# Altium schematic sheet Sway Cry Rev C - Sheet 1.SchDoc
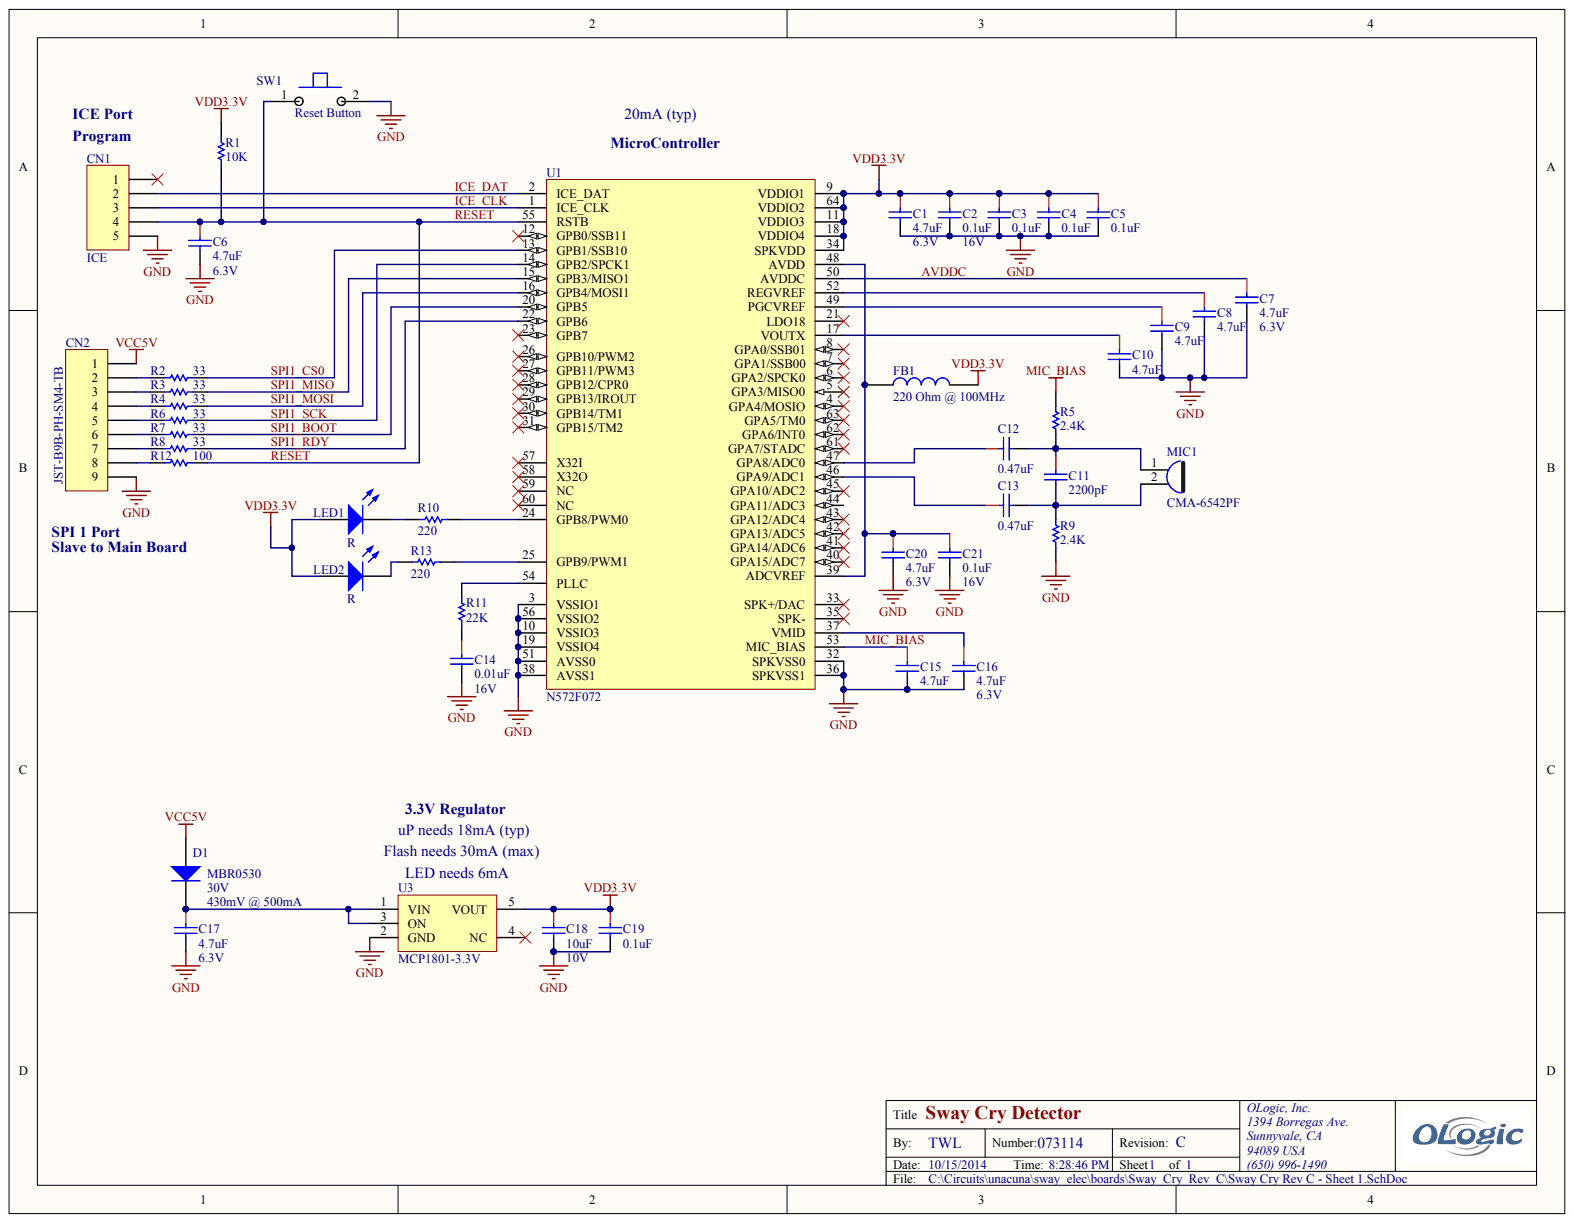
[diagram p0: Sway Cry Rev C - Sheet 1.SchDoc]

## Parts
C1  4.7uF  Voltage - Rated: 6.3V
C2  0.1uF  Voltage - Rated: 16V
C3  0.1uF  Voltage - Rated: 16V
C4  0.1uF
C5  0.1uF
C6  4.7uF  Voltage - Rated: 6.3V
C7  4.7uF  Voltage - Rated: 6.3V
C8  4.7uF
C9  4.7uF
C10  4.7uF
C11  2200pF
C12  0.47uF
C13  0.47uF
C14  0.01uF  Voltage - Rated: 16V
C15  4.7uF
C16  4.7uF  Voltage - Rated: 6.3V
C17  4.7uF  Voltage - Rated: 6.3V
C18  10uF  Voltage - Rated: 10V
C19  0.1uF
C20  4.7uF  Voltage - Rated: 6.3V
C21  0.1uF  Voltage - Rated: 16V
CN1  ICE
CN2  JST-B9B-PH-SM4-TB
D1  MBR0530  Voltage - DC Reverse (Vr) (Max): 30V
FB1  220 Ohm @ 100MHz
LED1  R
LED2  R
MIC1  CMA-6542PF
R1  10K
R2  33
R3  33
R4  33
R5  2.4K
R6  33
R7  33
R8  33
R9  2.4K
R10  220
R11  22K
R12  100
R13  220
SW1  Reset Button
U1  N572F072
U3  MCP1801-3.3V

## Nets
GND: C1.2 C2.2 C3.2 C4.2 C5.2 C6.2 C7.2 C8.2 C9.2 C10.2 C14.2 C15.2 C16.2 C17.2 C18.2 C19.2 C20.2 C21.2 CN1.5 CN2.9 R9.1 SW1.2 U1.3(VSSIO1) U1.10(VSSIO3) U1.19(VSSIO4) U1.32(SPKVSS0) U1.36(SPKVSS1) U1.38(AVSS1) U1.51(AVSS0) U1.56(VSSIO2) U3.2(GND)
VCC5V: CN2.1 D1.1
VDD3.3V: C1.1 C2.1 C3.1 C4.1 C5.1 C18.1 C19.1 FB1.2 LED1.1 LED2.1 R1.2 U1.9(VDDIO1) U1.11(VDDIO3) U1.18(VDDIO4) U1.34(SPKVDD) U1.64(VDDIO2) U3.5(VOUT)
AVDDC: C7.1 U1.50(AVDDC)
ICE_CLK: CN1.3 U1.1(ICE_CLK)
ICE_DAT: CN1.2 U1.2(ICE_DAT)
MIC_BIAS: C15.1 R5.2 U1.53(MIC_BIAS)
RESET: C6.1 CN1.4 R1.1 R12.1 SW1.1 U1.55(RSTB)
SPI1_BOOT: R7.1 U1.20(GPB5)
SPI1_CS0: R2.1 U1.13(GPB1/SSB10)
SPI1_MISO: R3.1 U1.15(GPB3/MISO1)
SPI1_MOSI: R4.1 U1.16(GPB4/MOSI1)
SPI1_RDY: R8.1 U1.22(GPB6)
SPI1_SCK: R6.1 U1.14(GPB2/SPCK1)
NetC8_1: C8.1 U1.52(REGVREF)
NetC9_1: C9.1 U1.49(PGCVREF)
NetC10_1: C10.1 U1.17(VOUTX)
NetC11_1: C11.1 C12.2 MIC1.1 R5.1
NetC11_2: C11.2 C13.2 MIC1.2 R9.2
NetC12_1: C12.1 U1.47(GPA8/ADC0)
NetC13_1: C13.1 U1.46(GPA9/ADC1)
NetC14_1: C14.1 R11.1
NetC16_1: C16.1 U1.37(VMID)
NetC17_1: C17.1 D1.2 U3.1(VIN) U3.3(ON)
NetC20_1: C20.1 C21.1 FB1.1 U1.39(ADCVREF) U1.48(AVDD)
NetCN2_2: CN2.2 R2.2
NetCN2_3: CN2.3 R3.2
NetCN2_4: CN2.4 R4.2
NetCN2_5: CN2.5 R6.2
NetCN2_6: CN2.6 R7.2
NetCN2_7: CN2.7 R8.2
NetCN2_8: CN2.8 R12.2
NetLED1_2: LED1.2 R10.1
NetLED2_2: LED2.2 R13.1
NetR10_2: R10.2 U1.24(GPB8/PWM0)
NetR11_2: R11.2 U1.54(PLLC)
NetR13_2: R13.2 U1.25(GPB9/PWM1)

Not connected: CN1 1; U1 4(GPA4/MOSIO) 5(GPA3/MISO0) 6(GPA2/SPCK0) 7(GPA1/SSB00) 8(GPA0/SSB01) 12(GPB0/SSB11) 21(LDO18) 23(GPB7) 26(GPB10/PWM2) 27(GPB11/PWM3) 28(GPB12/CPR0) 29(GPB13/IROUT) 30(GPB14/TM1) 31(GPB15/TM2) 33(SPK+/DAC) 35(SPK-) 40(GPA15/ADC7) 41(GPA14/ADC6) 42(GPA13/ADC5) 43(GPA12/ADC4) 44(GPA11/ADC3) 45(GPA10/ADC2) 57(X32I) 58(X32O) 59(NC) 60(NC) 61(GPA7/STADC) 62(GPA6/INT0) 63(GPA5/TM0); U3 4(NC)

## Library data of the parts
C1 C6 C7 C16 C17 C20 = (no symbol name): Manufacturer: TDK Corporation; Manufacturer Part Number: C1608X5R0J475K080AB; Capacitance: 4.7µF; Tolerance: ±10%; Temperature Coefficient: X5R; Supplier 1: Digi-Key; RoHS: RoHS Compliant; Category: Capacitors; Stock 1: 208265; Pricing 1: 1=0.18, 10=0.122, 100=0.0581, 250=0.04896, 500=0.041, 1000=0.033 (USD); Packaging: Cut Tape (CT); Mounting Type: Surface Mount, MLCC; Operating Temperature: -55°C ~ 85°C; Applications: General Purpose; Ratings: -; Package / Case: 0603 (1608 Metric); Size / Dimension: 0.063" L x 0.031" W (1.60mm x 0.80mm); Height - Seated (Max): -; Thickness (Max): 0.035" (0.90mm); Lead Spacing: -; Features: -; Lead Style: -; ComponentLink1URL: http://product.tdk.com/capacitor/mlcc/en/documents/mlcc_commercial_general_en.pdf; ComponentLink1Description: http://product.tdk.com/capacitor/mlcc/en/documents/mlcc_commercial_general_en.pdf; Supplier Part Number 1: 445-5178-1-ND
C2 C3 C21 = (no symbol name): Manufacturer: Kemet; Manufacturer Part Number: C0603C104K4RACTU; Capacitance: 0.1µF; Tolerance: ±10%; Temperature Coefficient: X7R; Supplier 1: Digi-Key; RoHS: RoHS Compliant; Category: Capacitors; Stock 1: 2360000; Pricing 1: 4000=0.00414, 8000=0.00378, 12000=0.0036, 28000=0.00342, 100000=0.00248 (USD); Packaging: Tape & Reel (TR); Mounting Type: Surface Mount, MLCC; Operating Temperature: -55°C ~ 125°C; Applications: General Purpose; Ratings: -; Package / Case: 0603 (1608 Metric); Size / Dimension: 0.063" L x 0.031" W (1.60mm x 0.80mm); Height - Seated (Max): -; Thickness (Max): 0.034" (0.87mm); Lead Spacing: -; Features: -; Lead Style: -; ComponentLink1URL: http://www.kemet.com/datasheets&C0603C104K4RACTU; ComponentLink1Description: http://www.kemet.com/datasheets&C0603C104K4RACTU; Supplier Part Number 1: 399-1096-2-ND
C4 C5 C19 = (no symbol name): Manufacturer: Kemet; Manufacturer Part Number: C0603C104K4RACTU; Capacitance: 0.1µF; Tolerance: ±10%; Temperature Coefficient: X7R; Voltage - Rated: 16V; Supplier 1: Digi-Key; RoHS: RoHS Compliant; Category: Capacitors; Stock 1: 2360000; Pricing 1: 4000=0.00414, 8000=0.00378, 12000=0.0036, 28000=0.00342, 100000=0.00248 (USD); Packaging: Tape & Reel (TR); Mounting Type: Surface Mount, MLCC; Operating Temperature: -55°C ~ 125°C; Applications: General Purpose; Ratings: -; Package / Case: 0603 (1608 Metric); Size / Dimension: 0.063" L x 0.031" W (1.60mm x 0.80mm); Height - Seated (Max): -; Thickness (Max): 0.034" (0.87mm); Lead Spacing: -; Features: -; Lead Style: -; ComponentLink1URL: http://www.kemet.com/datasheets&C0603C104K4RACTU; ComponentLink1Description: http://www.kemet.com/datasheets&C0603C104K4RACTU; Supplier Part Number 1: 399-1096-2-ND
C8-C10 C15 = (no symbol name): Manufacturer: TDK Corporation; Manufacturer Part Number: C1608X5R0J475K080AB; Capacitance: 4.7µF; Tolerance: ±10%; Temperature Coefficient: X5R; Voltage - Rated: 6.3V; Supplier 1: Digi-Key; RoHS: RoHS Compliant; Category: Capacitors; Stock 1: 208265; Pricing 1: 1=0.18, 10=0.122, 100=0.0581, 250=0.04896, 500=0.041, 1000=0.033 (USD); Packaging: Cut Tape (CT); Mounting Type: Surface Mount, MLCC; Operating Temperature: -55°C ~ 85°C; Applications: General Purpose; Ratings: -; Package / Case: 0603 (1608 Metric); Size / Dimension: 0.063" L x 0.031" W (1.60mm x 0.80mm); Height - Seated (Max): -; Thickness (Max): 0.035" (0.90mm); Lead Spacing: -; Features: -; Lead Style: -; ComponentLink1URL: http://product.tdk.com/capacitor/mlcc/en/documents/mlcc_commercial_general_en.pdf; ComponentLink1Description: http://product.tdk.com/capacitor/mlcc/en/documents/mlcc_commercial_general_en.pdf; Supplier Part Number 1: 445-5178-1-ND
C11 = (no symbol name): Manufacturer: Murata Electronics North America; Manufacturer Part Number: GRM1885C1H222JA01D; Voltage - Rated: 50V; Tolerance: ±5%; Temperature Coefficient: C0G, NP0; Supplier 1: Digi-Key; RoHS: RoHS Compliant; Category: Capacitors; Stock 1: 132131; Pricing 1: 1=0.12, 10=0.084, 50=0.0484, 100=0.0399, 250=0.0336, 500=0.02814, 1000=0.0231 (USD); Packaging: Cut Tape (CT); Capacitance: 2200pF; Mounting Type: Surface Mount, MLCC; Operating Temperature: -55°C ~ 125°C; Applications: General Purpose; Ratings: -; Package / Case: 0603 (1608 Metric); Size / Dimension: 0.063" L x 0.031" W (1.60mm x 0.80mm); Height - Seated (Max): -; Thickness (Max): 0.035" (0.90mm); Lead Spacing: -; Features: -; Lead Style: -; ComponentLink1URL: http://www.murata.com/products/catalog/pdf/c02e.pdf; ComponentLink1Description: http://www.murata.com/products/catalog/pdf/c02e.pdf; Supplier Part Number 1: 490-1459-1-ND
C12 C13 = (no symbol name): Manufacturer: Kemet; Manufacturer Part Number: C0603C474K4RACTU; Capacitance: 0.47µF; Voltage - Rated: 16V; Tolerance: ±10%; Temperature Coefficient: X7R; Supplier 1: Digi-Key; RoHS: RoHS Compliant; Category: Capacitors; Stock 1: 84679; Pricing 1: 1=0.15, 10=0.1, 50=0.0576, 100=0.0475, 250=0.04, 500=0.0335, 1000=0.0275 (USD); Packaging: Cut Tape (CT); Mounting Type: Surface Mount, MLCC; Operating Temperature: -55°C ~ 125°C; Applications: General Purpose; Ratings: -; Package / Case: 0603 (1608 Metric); Size / Dimension: 0.063" L x 0.031" W (1.60mm x 0.80mm); Height - Seated (Max): -; Thickness (Max): 0.034" (0.87mm); Lead Spacing: -; Features: -; Lead Style: -; ComponentLink1URL: http://www.kemet.com/docfinder?Partnumber=C0603C474K4RACTU; ComponentLink1Description: http://www.kemet.com/docfinder?Partnumber=C0603C474K4RACTU; Supplier Part Number 1: 399-4922-1-ND
C14 = (no symbol name): Manufacturer: Kemet; Manufacturer Part Number: C0603C103K4RACTU; Capacitance: 10000pF; Tolerance: ±10%; Temperature Coefficient: X7R; Supplier 1: Digi-Key; RoHS: RoHS Compliant; Category: Capacitors; Stock 1: 40000; Pricing 1: 4000=0.042, 8000=0.0399, 12000=0.0378, 28000=0.0357, 100000=0.02835 (USD); Packaging: Tape & Reel (TR); Mounting Type: Surface Mount, MLCC; Operating Temperature: -55°C ~ 125°C; Applications: General Purpose; Ratings: -; Package / Case: 0603 (1608 Metric); Size / Dimension: 0.063" L x 0.031" W (1.60mm x 0.80mm); Height - Seated (Max): -; Thickness (Max): 0.034" (0.87mm); Lead Spacing: -; Features: -; Lead Style: -; ComponentLink1URL: http://www.kemet.com/datasheets&C0603C103K4RACTU; ComponentLink1Description: http://www.kemet.com/datasheets&C0603C103K4RACTU; Supplier Part Number 1: 399-7841-2-ND
C18 = (no symbol name): Manufacturer: Taiyo Yuden; Manufacturer Part Number: LMK212F106ZG-T; Capacitance: 10µF; Tolerance: -20%, +80%; Temperature Coefficient: Y5V (F); Supplier 1: Digi-Key; RoHS: RoHS Compliant; Category: Capacitors; Stock 1: 194456; Pricing 1: 1=0.17, 10=0.115, 50=0.0662, 100=0.0546, 250=0.046, 500=0.03852, 1000=0.03163 (USD); Packaging: Cut Tape (CT); Mounting Type: Surface Mount, MLCC; Operating Temperature: -30°C ~ 85°C; Applications: General Purpose; Ratings: -; Package / Case: 0805 (2012 Metric); Size / Dimension: 0.079" L x 0.049" W (2.00mm x 1.25mm); Height - Seated (Max): -; Thickness (Max): 0.053" (1.35mm); Lead Spacing: -; Features: -; Lead Style: -; ComponentLink1URL: http://www.yuden.co.jp/or/product/category/capacitor/LMK212F106ZG-T.pdf; ComponentLink1Description: http://www.yuden.co.jp/or/product/category/capacitor/LMK212F106ZG-T.pdf; Supplier Part Number 1: 587-1312-1-ND
CN1 = (no symbol name): Manufacturer: FCI; Manufacturer Part Number: 68002-205HLF; Connector Type: Header, Unshrouded; Number of Positions: 5; Number of Positions Loaded: All; Pitch: 0.100" (2.54mm); Supplier 1: Digi-Key; Supplier Part Number 1: 609-4303-ND; RoHS: RoHS Compliant; Category: Connectors, Interconnects; Stock 1: 5252; Pricing 1: 1=0.27, 10=0.251, 25=0.2152, 50=0.1828, 100=0.1757, 250=0.15776, 500=0.15058, 1000=0.12548, 2500=0.11472 (USD); Packaging: Bulk; Contact Type: Male Pin; Number of Rows: 1; Row Spacing: -; Contact Mating Length: 0.230" (5.84mm); Mounting Type: Through Hole; Termination: Solder; Fastening Type: -; Features: -; Contact Finish: Gold or Gold, GXT™; Contact Finish Thickness: 15µin (0.38µm); Color: Black; ComponentLink1URL: http://portal.fciconnect.com/Comergent//fci/drawing/68000.pdf; ComponentLink1Description: http://portal.fciconnect.com/Comergent//fci/drawing/68000.pdf
CN2 = (no symbol name): Manufacturer: JST; MPN: B9B-PH-SM4-TB(LF)(SN); Vendor: Digikey; PN: 455-1741-1-ND; Connector Type: Header, Shrouded; Number of Positions: 9; Number of Positions Loaded: All; Pitch: 0.079" (2.00mm); Supplier 1: Digi-Key; Manufacturer Part Number: B9B-PH-SM4-TB(LF)(SN); RoHS: RoHS Compliant; Category: Connectors, Interconnects; Stock 1: 3129; Pricing 1: 1=1.03, 10=0.903, 50=0.8146, 100=0.7791, 250=0.70828, 500=0.63746 (USD); Packaging: Cut Tape (CT); Contact Type: Male Pin; Number of Rows: 1; Row Spacing: -; Contact Mating Length: -; Mounting Type: Surface Mount; Termination: Solder; Fastening Type: -; Features: Solder Retention; Contact Finish: Tin; Contact Finish Thickness: -; Color: Natural; ComponentLink1URL: http://www.jst-mfg.com/product/pdf/eng/ePH.pdf; ComponentLink1Description: http://www.jst-mfg.com/product/pdf/eng/ePH.pdf; Supplier Part Number 1: 455-1741-1-ND
D1 = (no symbol name): Manufacturer: ON Semiconductor; Manufacturer Part Number: MBR0530T3G; Diode Type: Schottky; Current - Average Rectified (Io): 500mA; Voltage - Forward (Vf) (Max) @ If: 430mV @ 500mA; Speed: Fast Recovery =< 500ns, > 200mA (Io); Current - Reverse Leakage @ Vr: 130µA @ 30V; Thermal Resistance: 150°C/W Jl; Supplier 1: Digi-Key; RoHS: RoHS Compliant; Category: Discrete Semiconductor Products; Stock 1: 24594; Pricing 1: 1=0.38, 10=0.341, 25=0.2456, 100=0.1911, 250=0.12004, 500=0.1023, 1000=0.0697, 2500=0.0628, 5000=0.05459 (USD); Packaging: Cut Tape (CT); Reverse Recovery Time (trr): -; Capacitance @ Vr, F: -; Mounting Type: Surface Mount; Package / Case: SOD-123; Supplier Device Package: SOD-123; Operating Temperature - Junction: -65°C ~ 125°C; ComponentLink1URL: http://www.onsemi.com/pub_link/Collateral/MBR0530T1-D.PDF; ComponentLink1Description: http://www.onsemi.com/pub_link/Collateral/MBR0530T1-D.PDF; Supplier Part Number 1: MBR0530T3GOSCT-ND
FB1 = (no symbol name): Manufacturer: Laird-Signal Integrity Products; Manufacturer Part Number: MI0603L221R-10; Current Rating: 2A; DC Resistance (DCR): 50 mOhm Max; Filter Type: Differential Mode - Single; Supplier 1: Digi-Key; RoHS: RoHS Compliant; Category: Filters; Stock 1: 195609; Pricing 1: 1=0.1, 10=0.08, 25=0.0732, 50=0.0658, 100=0.0483, 250=0.03512, 500=0.03218, 1000=0.0278 (USD); Packaging: Cut Tape (CT); Impedance @ Frequency: 220 Ohm @ 100MHz; Package / Case: 0603 (1608 Metric); Mounting Type: Surface Mount; Height (Max): 0.037" (0.95mm); Size / Dimension: 0.063" L x 0.031" W (1.60mm x 0.80mm); ComponentLink1URL: http://www.lairdtech.com/WorkArea/linkit.aspx?LinkIdentifier=id&ItemID=5724; ComponentLink1Description: http://www.lairdtech.com/WorkArea/linkit.aspx?LinkIdentifier=id&ItemID=5724; Supplier Part Number 1: 240-2558-1-ND; ClassName: *
LED1 LED2 = (no symbol name): Manufacturer: Lite-On Inc; Manufacturer Part Number: LTST-C191KRKT; Color: Red; Wavelength - Dominant: 631nm; Wavelength - Peak: 639nm; Millicandela Rating: 54mcd; Voltage - Forward (Vf) (Typ): 2V; Current - Test: 20mA; Viewing Angle: 130°; Lens Type: Clear; Lens Style/Size: Rectangle with Flat Top, 1.1mm x 0.8mm; Supplier 1: Digi-Key; RoHS: RoHS Compliant; Category: Optoelectronics; Stock 1: 158612; Pricing 1: 1=0.3, 10=0.238, 100=0.1296, 250=0.0864, 500=0.07344, 1000=0.05616, 2500=0.05076 (USD); Packaging: Cut Tape (CT); Package / Case: 0603 (1608 Metric); Size / Dimension: 1.60mm L x 0.80mm W; Height: 0.55mm; Mounting Type: Surface Mount; Luminous Flux @ Current - Test: -; ComponentLink1URL: http://optoelectronics.liteon.com/upload/download/DS22-2000-223/S_110_LTST-C191KRKT.pdf; ComponentLink1Description: http://optoelectronics.liteon.com/upload/download/DS22-2000-223/S_110_LTST-C191KRKT.pdf; Supplier Part Number 1: 160-1447-1-ND
MIC1 = (no symbol name): Manufacturer: CUI Inc; Output Type: Analog; Direction: Omnidirectional; Frequency Range: 50Hz ~ 20kHz; Sensitivity: -42dB ±3dB @ 94dB SPL; S/N Ratio: 60dB; Impedance: 2.2 kOhm; Voltage - Rated: 4.5 ~ 10V; Current - Supply: 500µA; Port Location: Top; Seal Rating: None; Shape: Circular; Supplier 1: Digi-Key; Manufacturer Part Number: CMA-6542PF; RoHS: RoHS Compliant; Category: Audio Products; Stock 1: 16845; Pricing 1: 1=1.18, 10=0.917, 100=0.6998, 500=0.5598, 1000=0.4976, 2500=0.41363 (USD); Packaging: Bulk; Type: Electret Condenser; Termination: PC Pins; Size / Dimension: 9.70mm Dia; Height (Max): 6.70mm; ComponentLink1URL: http://media.digikey.com/pdf/Data%20Sheets/CUI%20Inc%20All%20Brands%20PDFs/CMA-6542PF.pdf; ComponentLink1Description: http://media.digikey.com/pdf/Data%20Sheets/CUI%20Inc%20All%20Brands%20PDFs/CMA-6542PF.pdf; Supplier Part Number 1: 102-1720-ND
R1 = (no symbol name): Manufacturer: Panasonic Electronic Components; Manufacturer Part Number: ERJ-3GEYJ103V; Tolerance: ±5%; Power (Watts): 0.1W, 1/10W; Temperature Coefficient: ±200ppm/°C; Supplier 1: Digi-Key; RoHS: RoHS Compliant; Category: Resistors; Stock 1: 4724855; Pricing 1: 1=0.1, 50=0.0126, 100=0.0096, 250=0.00716, 500=0.00528, 1000=0.00352, 2500=0.00297 (USD); Packaging: Cut Tape (CT); Resistance (Ohms): 10k; Composition: Thick Film; Features: -; Package / Case: 0603 (1608 Metric); Supplier Device Package: 0603; Size / Dimension: 0.063" L x 0.031" W (1.60mm x 0.80mm); Height: 0.022" (0.55mm); Number of Terminations: 2; ComponentLink1URL: http://industrial.panasonic.com/www-cgi/jvcr13pz.cgi?E+PZ+3+AOA0001+ERJ3GEYJ103V+7+WW; ComponentLink1Description: http://industrial.panasonic.com/www-cgi/jvcr13pz.cgi?E+PZ+3+AOA0001+ERJ3GEYJ103V+7+WW; Supplier Part Number 1: P10KGCT-ND
R2-R4 R6-R8 = (no symbol name): Manufacturer: Panasonic Electronic Components; Manufacturer Part Number: ERJ-3GEYJ330V; Tolerance: ±5%; Power (Watts): 0.1W, 1/10W; Temperature Coefficient: ±200ppm/°C; Supplier 1: Digi-Key; RoHS: RoHS Compliant; Category: Resistors; Stock 1: 499360; Pricing 1: 1=0.1, 50=0.0126, 100=0.0096, 250=0.00716, 500=0.00528, 1000=0.00352, 2500=0.00297 (USD); Packaging: Cut Tape (CT); Resistance (Ohms): 33; Composition: Thick Film; Features: -; Package / Case: 0603 (1608 Metric); Supplier Device Package: 0603; Size / Dimension: 0.063" L x 0.031" W (1.60mm x 0.80mm); Height: 0.022" (0.55mm); Number of Terminations: 2; ComponentLink1URL: http://industrial.panasonic.com/www-cgi/jvcr13pz.cgi?E+PZ+3+AOA0001+ERJ3GEYJ330V+7+WW; ComponentLink1Description: http://industrial.panasonic.com/www-cgi/jvcr13pz.cgi?E+PZ+3+AOA0001+ERJ3GEYJ330V+7+WW; Supplier Part Number 1: P33GCT-ND
R5 R9 = (no symbol name): Manufacturer: Panasonic Electronic Components; Manufacturer Part Number: ERJ-3GEYJ242V; Tolerance: ±5%; Power (Watts): 0.1W, 1/10W; Temperature Coefficient: ±200ppm/°C; Supplier 1: Digi-Key; RoHS: RoHS Compliant; Category: Resistors; Stock 1: 125378; Pricing 1: 1=0.1, 50=0.0126, 100=0.0096, 250=0.00716, 500=0.00528, 1000=0.00352, 2500=0.00297 (USD); Packaging: Cut Tape (CT); Resistance (Ohms): 2.4k; Composition: Thick Film; Features: Automotive AEC-Q200 Qualified; Package / Case: 0603 (1608 Metric); Supplier Device Package: 0603; Size / Dimension: 0.063" L x 0.031" W (1.60mm x 0.80mm); Height: 0.022" (0.55mm); Number of Terminations: 2; ComponentLink1URL: http://industrial.panasonic.com/www-cgi/jvcr13pz.cgi?E+PZ+3+AOA0001+ERJ3GEYJ242V+7+WW; ComponentLink1Description: http://industrial.panasonic.com/www-cgi/jvcr13pz.cgi?E+PZ+3+AOA0001+ERJ3GEYJ242V+7+WW; Supplier Part Number 1: P2.4KGCT-ND
R10 R13 = (no symbol name): Manufacturer: Panasonic Electronic Components; Manufacturer Part Number: ERJ-3GEYJ221V; Tolerance: ±5%; Power (Watts): 0.1W, 1/10W; Temperature Coefficient: ±200ppm/°C; Supplier 1: Digi-Key; RoHS: RoHS Compliant; Category: Resistors; Stock 1: 526426; Pricing 1: 1=0.1, 50=0.0126, 100=0.0096, 250=0.00716, 500=0.00528, 1000=0.00352, 2500=0.00297 (USD); Packaging: Cut Tape (CT); Resistance (Ohms): 220; Composition: Thick Film; Features: -; Package / Case: 0603 (1608 Metric); Supplier Device Package: 0603; Size / Dimension: 0.063" L x 0.031" W (1.60mm x 0.80mm); Height: 0.022" (0.55mm); Number of Terminations: 2; ComponentLink1URL: http://industrial.panasonic.com/www-cgi/jvcr13pz.cgi?E+PZ+3+AOA0001+ERJ3GEYJ221V+7+WW; ComponentLink1Description: http://industrial.panasonic.com/www-cgi/jvcr13pz.cgi?E+PZ+3+AOA0001+ERJ3GEYJ221V+7+WW; Supplier Part Number 1: P220GCT-ND
R11 = (no symbol name): Manufacturer: Samsung Electro-Mechanics America, Inc; Manufacturer Part Number: RC1608J223CS; Tolerance: ±5%; Power (Watts): 0.1W, 1/10W; Temperature Coefficient: ±100ppm/°C; Supplier 1: Digi-Key; RoHS: RoHS Compliant; Category: Resistors; Stock 1: 16999; Pricing 1: 1=0.1, 10=0.011, 25=0.008, 50=0.006, 100=0.0045, 250=0.0034, 500=0.00274, 1000=0.00201, 2500=0.00174 (USD); Packaging: Cut Tape (CT); Resistance (Ohms): 22k; Composition: Thick Film; Features: -; Package / Case: 0603 (1608 Metric); Supplier Device Package: 0603 (1608 Metric); Size / Dimension: 0.063" L x 0.031" W (1.60mm x 0.80mm); Height: 0.022" (0.55mm); Number of Terminations: 2; ComponentLink1URL: http://www.samsungsem.com/servlet/FileDownload?filename=productcatalog/pdf/Chip_Resistor.pdf; ComponentLink1Description: http://www.samsungsem.com/servlet/FileDownload?filename=productcatalog/pdf/Chip_Resistor.pdf; Supplier Part Number 1: 1276-5094-1-ND
R12 = (no symbol name): Manufacturer: Panasonic Electronic Components; Manufacturer Part Number: ERJ-3GEYJ101V; Tolerance: ±5%; Power (Watts): 0.1W, 1/10W; Temperature Coefficient: ±200ppm/°C; Supplier 1: Digi-Key; RoHS: RoHS Compliant; Category: Resistors; Stock 1: 1547220; Pricing 1: 1=0.1, 50=0.0126, 100=0.0096, 250=0.00716, 500=0.00528, 1000=0.00352, 2500=0.00297 (USD); Packaging: Cut Tape (CT); Resistance (Ohms): 100; Composition: Thick Film; Features: -; Package / Case: 0603 (1608 Metric); Supplier Device Package: 0603; Size / Dimension: 0.063" L x 0.031" W (1.60mm x 0.80mm); Height: 0.022" (0.55mm); Number of Terminations: 2; ComponentLink1URL: http://industrial.panasonic.com/www-cgi/jvcr13pz.cgi?E+PZ+3+AOA0001+ERJ3GEYJ101V+7+WW; ComponentLink1Description: http://industrial.panasonic.com/www-cgi/jvcr13pz.cgi?E+PZ+3+AOA0001+ERJ3GEYJ101V+7+WW; Supplier Part Number 1: P100GCT-ND
U1 = (no symbol name): Manufacturer: Nuvoton; MPN: N572F072_P072
U3 = (no symbol name): Manufacturer: Microchip; MPN: MCP1801T-3302I/OT; PN: MCP1801T-3302I/OTCT-ND; Vendor: Digikey; Regulator Topology: Positive Fixed; Voltage - Output: 3.3V; Current - Output: 150mA; Voltage - Dropout (Typical): 0.2V @ 100mA; Number of Regulators: 1; Voltage - Input: Up to 10V; Supplier 1: Digi-Key; Supplier Part Number 1: MCP1801T-3302I/OTCT-ND; Manufacturer Part Number: MCP1801T-3302I/OT; RoHS: RoHS Compliant; Category: Integrated Circuits (ICs); Stock 1: 23784; Pricing 1: 1=0.5, 10=0.42, 25=0.35, 100=0.32 (USD); Packaging: Cut Tape (CT); Current - Limit (Min): -; Operating Temperature: -40°C ~ 85°C; Mounting Type: Surface Mount; Package / Case: SC-74A, SOT-753; Supplier Device Package: SOT-23-5; ComponentLink1URL: http://www.microchip.com/mymicrochip/filehandler.aspx?ddocname=en531339; ComponentLink1Description: http://www.microchip.com/mymicrochip/filehandler.aspx?ddocname=en531339
